# Altium schematic sheet Power.schdoc
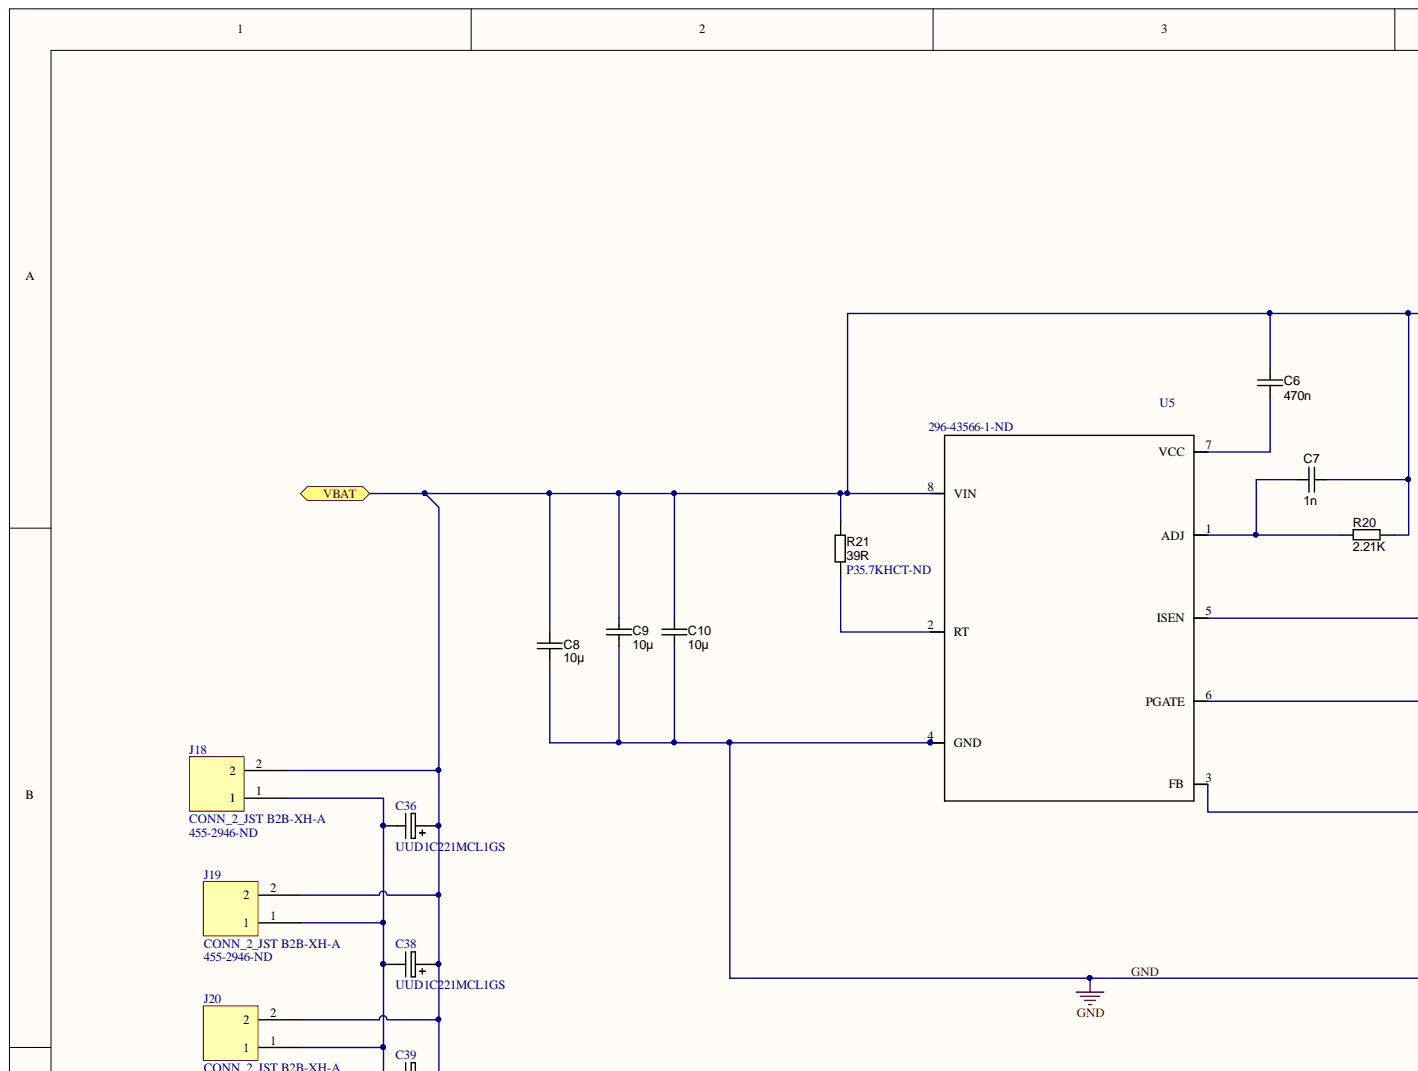
[diagram p0: Power.schdoc, top left]
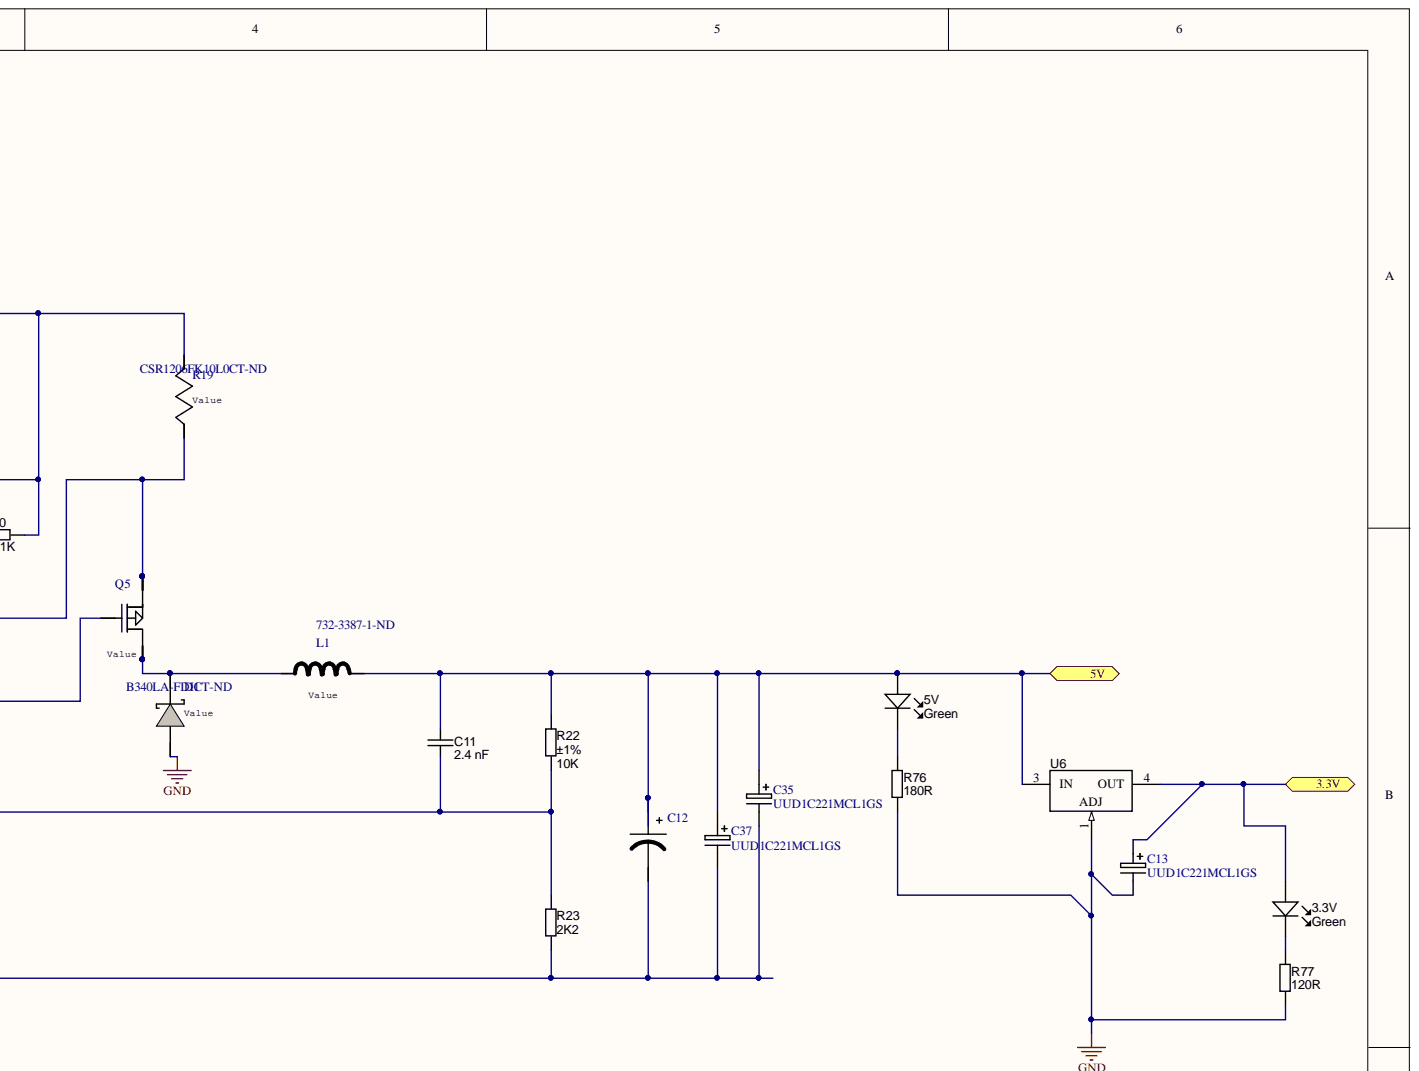
[diagram p1: Power.schdoc, top right]
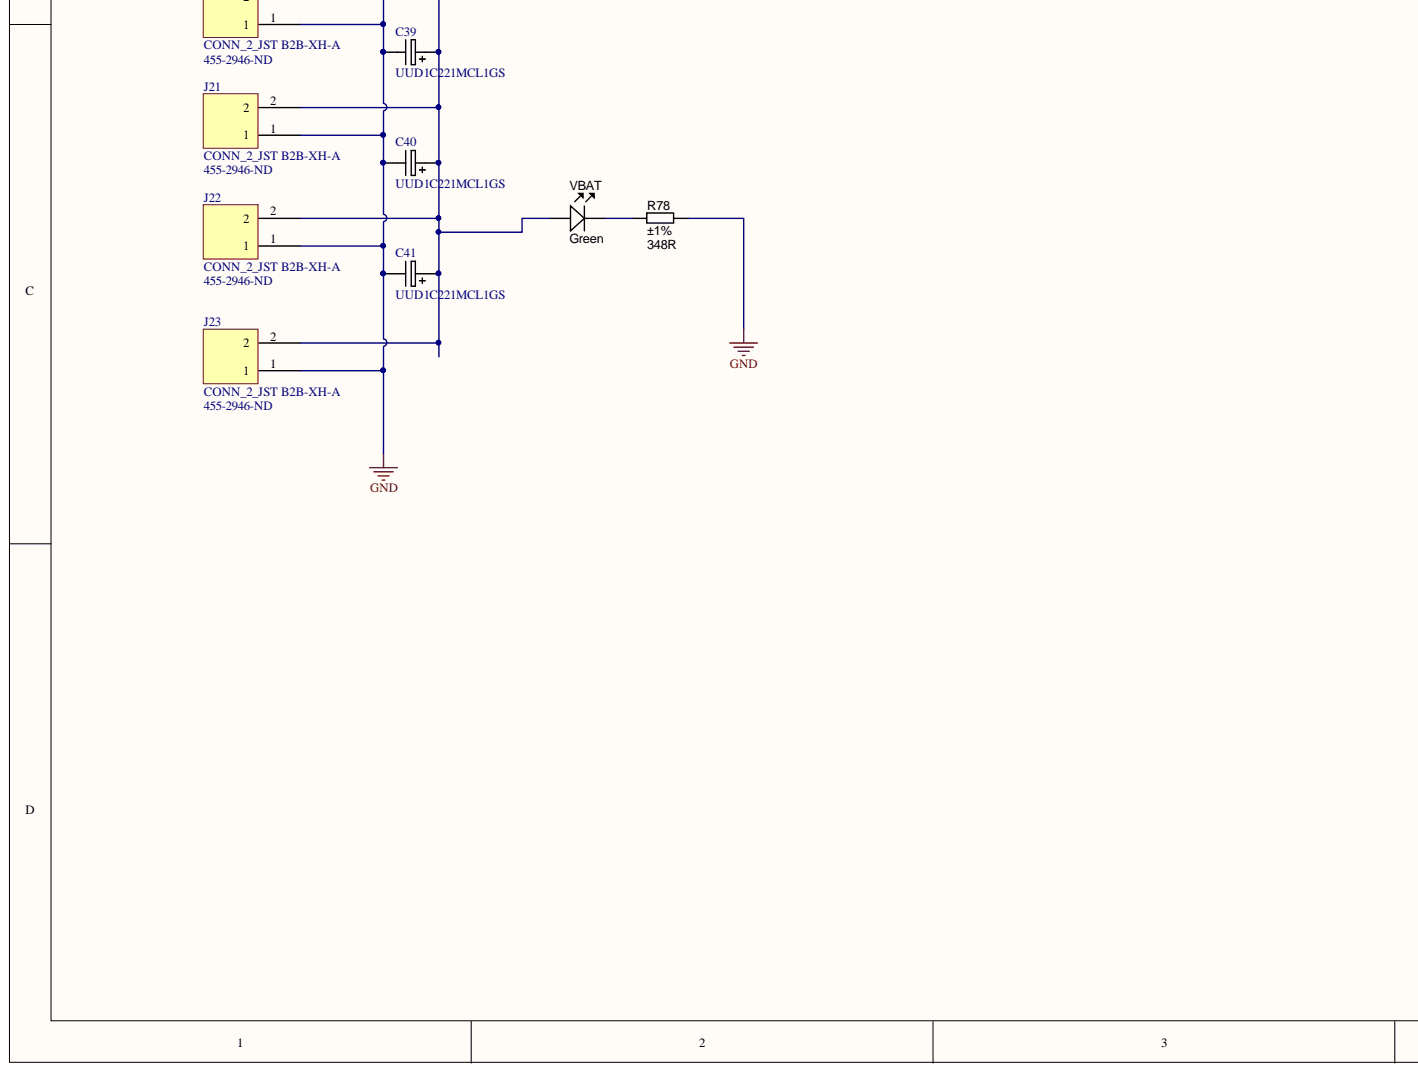
[diagram p2: Power.schdoc, bottom left]
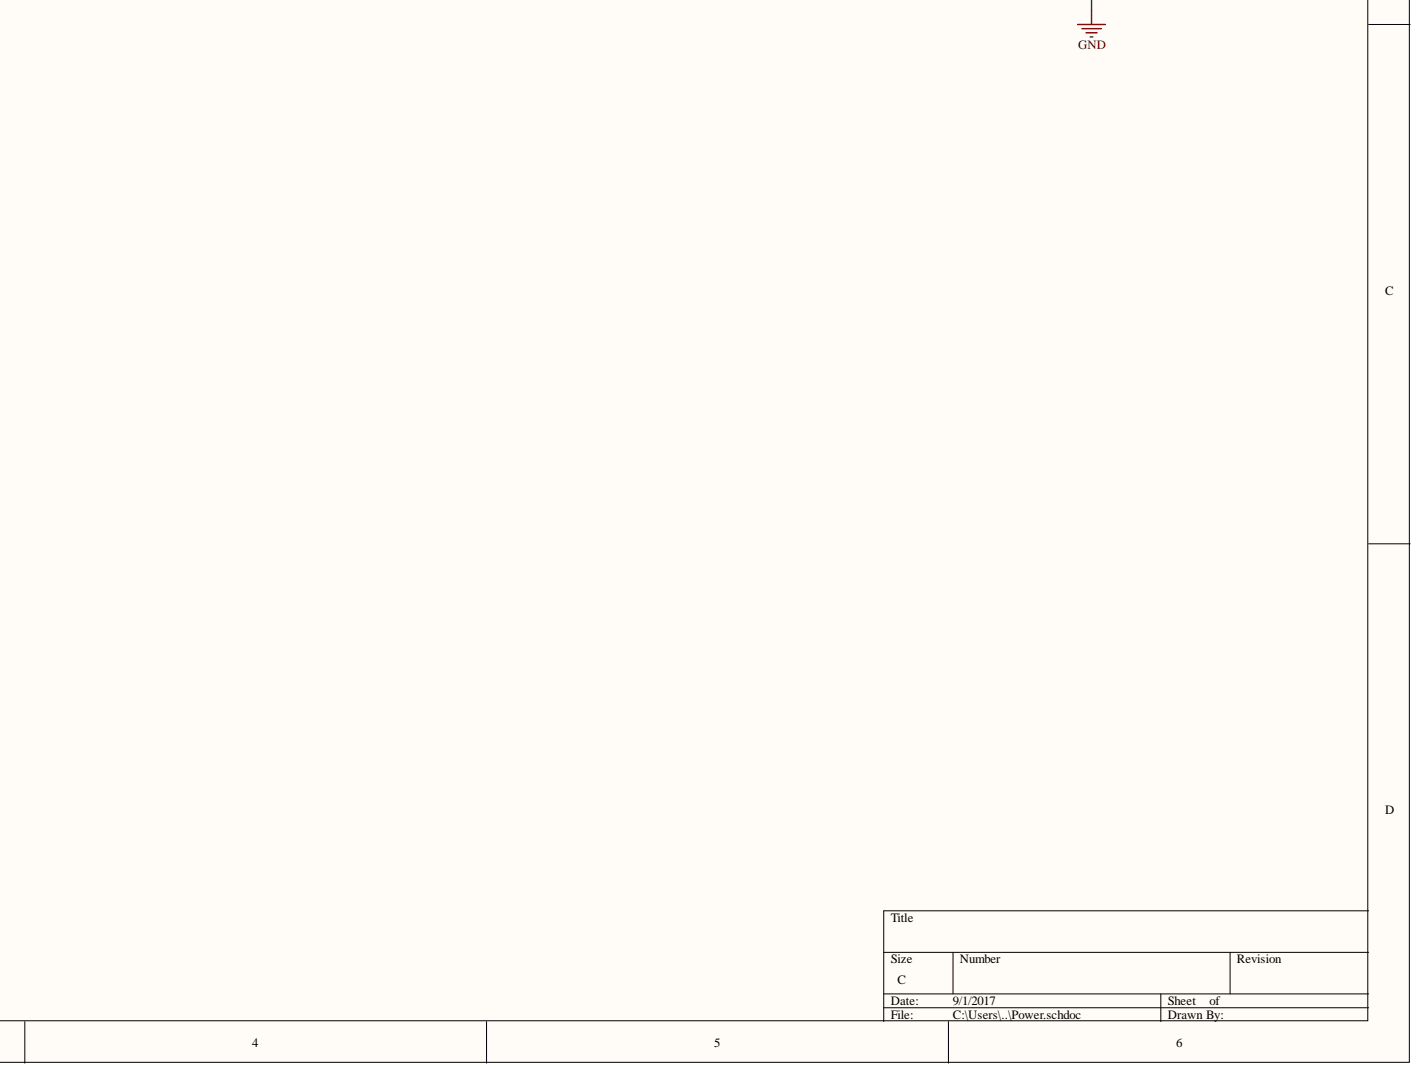
[diagram p3: Power.schdoc, bottom right]

## Parts
3.3V  Green  Voltage - Forward (Vf) @ 20mA: 3.3V  [LED-0603-GREEN]
5V  Green  [LED-0603-GREEN]
C6  value 470n  [CAPC2012X135N]  (hidden comment: approved sub OK)
C7  value 1n  [CAPC1608X90N]  (hidden comment: approved sub OK)
C8  value 10µ  [CAPC3216X180N]  (hidden comment: approved sub OK)
C9  value 10µ  [CAPC3216X180N]  (hidden comment: approved sub OK)
C10  value 10µ  [CAPC3216X180N]  (hidden comment: approved sub OK)
C11  value 2.4 nF  [CAPC1608X90N]  (hidden comment: approved sub OK)
C12  6TPU47MSI  [CAPC2012X135N]  (value not drawn)
C13  UUD1C221MCL1GS  [NICH 6.3mm 7.7mm]
C35  UUD1C221MCL1GS  [NICH 6.3mm 7.7mm]
C36  UUD1C221MCL1GS  [NICH 6.3mm 7.7mm]
C37  UUD1C221MCL1GS  [NICH 6.3mm 7.7mm]
C38  UUD1C221MCL1GS  [NICH 6.3mm 7.7mm]
C39  UUD1C221MCL1GS  [NICH 6.3mm 7.7mm]
C40  UUD1C221MCL1GS  [NICH 6.3mm 7.7mm]
C41  UUD1C221MCL1GS  [NICH 6.3mm 7.7mm]
D1  Supplier Part Number 1: B340LA-FDICT-ND  [SMA]  (hidden comment: B340LA-13-F)
J18  CONN_2_JST B2B-XH-A  Supplier Part Number 1: 455-2946-ND  [CONN_JST_XT_TH_2]
J19  CONN_2_JST B2B-XH-A  Supplier Part Number 1: 455-2946-ND  [CONN_JST_XT_TH_2]
J20  CONN_2_JST B2B-XH-A  Supplier Part Number 1: 455-2946-ND  [CONN_JST_XT_TH_2]
J21  CONN_2_JST B2B-XH-A  Supplier Part Number 1: 455-2946-ND  [CONN_JST_XT_TH_2]
J22  CONN_2_JST B2B-XH-A  Supplier Part Number 1: 455-2946-ND  [CONN_JST_XT_TH_2]
J23  CONN_2_JST B2B-XH-A  Supplier Part Number 1: 455-2946-ND  [CONN_JST_XT_TH_2]
L1  Supplier Part Number 1: 732-3387-1-ND  [IND_WE-LHMI-SM]  (hidden comment: 74437368022)
Q5  CSD25402Q3A  [TRANS_NEXFET_Q3A]  (value not drawn)
R19  CSR1206FK10L0  Supplier Part Number 1: CSR1206FK10L0CT-ND  [1206]
R20  value 2.21K  [RESC1608X55N]  (hidden comment: Thick Film)
R21  value 39R  Supplier Part Number 1: P35.7KHCT-ND  [RESC1608X55N]  (hidden comment: Thick Film)
R22  value 10K  Tolerance: ±1%  [RESC1608X55N]  (hidden comment: Thick Film)
R23  value 2K2  [RESC1608X55N]  (hidden comment: Thick Film)
R76  value 180R  [RESC1608X55N]  (hidden comment: Thick Film)
R77  value 120R  [RESC1608X55N]  (hidden comment: Thick Film)
R78  value 348R  Tolerance: ±1%  [RESC1608X55N]  (hidden comment: Thin Film)
U5  Supplier Part Number 1: 296-43566-1-ND  [MUY08A]  (hidden comment: LM25085AMY/NOPB)
U6  LD1085D2T33R  [D2PAK-3]  (value not drawn)
VBAT  Green  [LED-0603-GREEN]

## Ports
3.3V, 5V, VBAT

## Nets
3.3V: 3.3V.A C13.+ U6.4 ; port 3.3V
5V: 5V.A C11.1 C12.1 C35.+ C37.+ L1.2 R22.1 U6.3 ; port 5V
GND: C8.2 C9.2 C10.2 C12.2 C13.- C35.- C36.- C37.- C38.- C39.- C40.- C41.- D1.2 J18.1 J19.1 J20.1 J21.1 J22.1 J23.1 R23.2 R76.2 R77.2 R78.2 U5.4(GND) U5.9 U6.1
VBAT: C6.1 C7.2 C8.1 C9.1 C10.1 C36.+ C38.+ C39.+ C40.+ C41.+ J18.2 J19.2 J20.2 J21.2 J22.2 J23.2 R19.1 R20.2 R21.1 U5.8(VIN) VBAT.A ; port VBAT
Net3.3V_K: 3.3V.K R77.1
Net5V_K: 5V.K R76.1
NetC6_2: C6.2 U5.7(VCC)
NetC7_1: C7.1 R20.1 U5.1(ADJ)
NetC11_2: C11.2 R22.2 R23.1 U5.3(FB)
NetD1_1: D1.1 L1.1 Q5.1 Q5.2 Q5.3
NetQ5_4: Q5.4 U5.6(PGATE)
NetQ5_5: Q5.5 Q5.6 Q5.7 Q5.8 Q5.9 R19.2 U5.5(ISEN)
NetR21_2: R21.2 U5.2(RT)
NetR78_1: R78.1 VBAT.K

## Library data of the parts
3.3V = LED_SMD_Green_0603_525nm_350mcd: LED 0603 SMD Green 525nm 350mcd 20mA; Lens Style/Size: Rectangle with Flat Top, 1.2mm x 0.8mm; Ratings: 200mcd min; 350 mcd typ @ 20mA; SCDType: source; Viewing Angle: 120°; Voltage - Forward (Vf) @ 10mA: 3.1V; Color: Green; Component Kind: Standard; ComponentLink1Description: http://www.mouser.com/ds/2/216/APT1608ZGCK-262051.pdf; ComponentLink1URL: http://www.mouser.com/ds/2/216/APT1608ZGCK-262051.pdf; ComponentLink2Description: APT1608-WAP24 Datasheet; ComponentLink2URL: \\SVFI2\PBLShare\Eng\Electrical Engineering\Hardware\Altium\Libraries and Templates\Unreleased Components\Part Number Request 2013-10-02\APT1608-WAP24(Ver.1B).pdf; Current - Test: 20mA; Library Name: PBL - Light Sources.SCHLIB; Material: InGaN; Package / Case: 0603 (1608 metric); Supplier 1: Digi-Key; Supplier Part Number 1: 160-1183-1-ND
5V VBAT = LED_SMD_Green_0603_525nm_350mcd: LED 0603 SMD Green 525nm 350mcd 20mA; Lens Style/Size: Rectangle with Flat Top, 1.2mm x 0.8mm; Ratings: 200mcd min; 350 mcd typ @ 20mA; SCDType: source; Viewing Angle: 120°; Voltage - Forward (Vf) @ 10mA: 3.1V; Voltage - Forward (Vf) @ 20mA: 3.3V; Color: Green; Component Kind: Standard; ComponentLink1Description: http://www.mouser.com/ds/2/216/APT1608ZGCK-262051.pdf; ComponentLink1URL: http://www.mouser.com/ds/2/216/APT1608ZGCK-262051.pdf; ComponentLink2Description: APT1608-WAP24 Datasheet; ComponentLink2URL: \\SVFI2\PBLShare\Eng\Electrical Engineering\Hardware\Altium\Libraries and Templates\Unreleased Components\Part Number Request 2013-10-02\APT1608-WAP24(Ver.1B).pdf; Current - Test: 20mA; Library Name: PBL - Light Sources.SCHLIB; Material: InGaN; Package / Case: 0603 (1608 metric); Supplier 1: Digi-Key; Supplier Part Number 1: 160-1183-1-ND
C6 = CAPC_470n_0805_X7R_16V_10%: Capacitor SMD Cer 470nF 10% 16V X7R 0805; Manufacturer 1: AVX Corporation; Manufacturer Part Number 1: 0805YC474KAT2A; Ratings: 16V; X7R; SCDType: undef; Component Kind: Standard; Library Name: PBL - Capacitors.SCHLIB; Operating Temperature: -55°C ~ 125°C; Package / Case: 0805 (2012 metric); Supplier 1: Digi-Key; Supplier Part Number 1: 478-1403-1-ND; Tolerance: ±10%
C7 = CAPC_1n_0603_X7R_100V_10%: Capacitor SMD Cer 1nF 10% 100V X7R 0603; Manufacturer 1: Murata Electronics North America; Manufacturer Part Number 1: GCM188R72A102KA37D; Material: ceramic; Ratings: 100V; X7R; SCDType: spec; Component Kind: Standard; ComponentLink1Description: http://search.murata.co.jp/Ceramy/image/img/PDF/ENG/GCM188R72A102KA37.pdf; ComponentLink1URL: http://search.murata.co.jp/Ceramy/image/img/PDF/ENG/GCM188R72A102KA37.pdf; Library Name: PBL - Capacitors.SCHLIB; Operating Temperature: -55°C ~ 125°C; Package / Case: 0603 (1608 metric); Size / Dimension: 0.063" L x 0.031" W (1.60mm x 0.80mm); Supplier 1: Digi-Key; Supplier Part Number 1: 490-4780-1-ND; Thickness (Max): 0.035" (0.90mm); Tolerance: ±10%
C8-C10 = CAPC_10u_1206_16V: Capacitor SMD Cer 10uF 10% 16V X7R 1206; Manufacturer 1: Kemet; Manufacturer Part Number 1: C1206C106K4RACTU; Material: ceramic; Ratings: 16V; X7R; SCDType: spec; Component Kind: Standard; Library Name: PBL - Capacitors.SCHLIB; Package / Case: 1206 (3216 metric); Supplier 1: Digi-Key; Supplier Part Number 1: 399-3525-1-ND; Tolerance: ±10%
C11 = CAPC_2n4_50V_0603: Capacitor SMD Cer 2400pF  50V NP0 0603; Ratings: 100V; NP0; SCDType: source*; Tolerance: ±0.25pF; Component Kind: Standard; Library Name: PBL - Capacitors.SCHLIB; Operating Temperature: -55°C ~ 125°C; Package / Case: 0603 (1608 metric); Supplier 1: Digi-Key; Supplier Part Number 1: 490-3282-1-ND
C12 = CAPT_47u_6.3V_0805_6TPU47MSI: CAP TANT POLY 47UF 6.3V 0805; Manufacturer_Name: Panasonic; Component Kind: Standard; Library Name: PBL - Capacitors.SCHLIB; Manufacturer_Part_Number: 6TPU47MSI; RefDes: RefDes; Supplier 1: Digi-Key; Supplier Part Number 1: P16212CT-ND; TYPE: TYPE; Value: Value; Vendor: Panasonic
C13 C35-C41 = NICHICON_220uF_16V: GENERIC CAPACITOR POLARISED; Component Kind: Standard; Library Name: SMD Capacitors Electrolytic.SchLib; Supplier 1: Digi-Key; Supplier Part Number 1: 493-2264-1-ND
D1 = B340LA-13-F: Imported; Manufacturer_Name: Diodes Inc.; Component Kind: Standard; Library Name: 2016-02-21_13-02-55.SchLib; Manufacturer_Part_Number: B340LA-13-F; RefDes: RefDes; Supplier 1: Digi-Key; Type: Type; Value: Value; Vendor: Diodes Inc.
J18-J23 = CONN_2_JST B2B-XH-A: CONN HEADER XH SIDE 2POS 2.5MM; Component Kind: Standard; Supplier 1: Digi-Key; Library Name: RyanMasterLib.SchLib
L1 = 74437368022: Imported; Manufacturer: Wurth Elektronik; Vendor: Wurth Elektronik eiSos; Component Kind: Standard; Library Name: 2016-02-21_13-02-55.SchLib; Manufacturer_Name: Wurth Elektronik; Manufacturer_Part_Number: 74437368022; PACKAGE_DESIGNATOR: IND_WE-LHMI-SM; RefDes: RefDes; Supplier 1: Digi-Key; TYPE: TYPE; Value: Value
Q5 = CSD25402Q3A: Imported; Manufacturer: Texas Instruments; ChType: P; CompType: MOSFET; IdsMax_A: -15; PIN_COUNT: 9; QgTyp_nC: 7.5; Rdson45_ohm: 0.0077; Value1: 0.0077 ohm; Value2: 7.5 nC; VdsMax_V: -20; Vgs_V: 12; Component Kind: Standard; LatestRevisionNote: Added parts.; Library Name: 2016-02-21_13-02-55.SchLib; Manufacturer_Name: Texas Instruments; Manufacturer_Part_Number: CSD25402Q3A; MountType: SMT; PackageReference: SON 3.3x3.3mm; PartNumber: CSD25402Q3A; Published: 2008-06-19; RefDes: RefDes; RoHS: Y; Supplier 1: Digi-Key; Supplier Part Number 1: 296-38916-1-ND; TYPE: TYPE; Value: Value
R19 = CSR1206FK10L0: Imported; Manufacturer_Name: Stackpole Electronics Inc; Component Kind: Standard; Library Name: 2016-02-21_13-02-55.SchLib; Manufacturer_Part_Number: CSR1206FK10L0; RefDes: RefDes; Supplier 1: Digi-Key; TYPE: TYPE; Value: Value; Vendor: Stackpole Electronics Inc
R20 = RES_2.21K_0603_1%: Resistor SMD chip 2.21k Ohm 0.1W 1% 0603; Ratings: 1/10 W; SCDType: spec; Temperature Coefficient: ±100ppm/°C; Tolerance: ±5%; Component Kind: Standard; ComponentLink1Description: http://www.yageo.com/documents/recent/PYu-RC0603_51_RoHS_L_4.pdf; ComponentLink1URL: http://www.yageo.com/documents/recent/PYu-RC0603_51_RoHS_L_4.pdf; ComponentLink2Description: http://www.samsungsem.com/servlet/FileDownload?filename=productcatalog/pdf/Chip_Resistor.pdf; ComponentLink2URL: http://www.samsungsem.com/servlet/FileDownload?filename=productcatalog/pdf/Chip_Resistor.pdf; ComponentLink3Description: http://www.seielect.com/Catalog/SEI-RMCF_RMCP.pdf; ComponentLink3URL: http://www.seielect.com/Catalog/SEI-RMCF_RMCP.pdf; ComponentLink4Description: http://rohmfs.rohm.com/en/products/databook/datasheet/passive/resistor/chip_resistor/mcr_g.pdf; ComponentLink4URL: http://rohmfs.rohm.com/en/products/databook/datasheet/passive/resistor/chip_resistor/mcr_g.pdf; ComponentLink5Description: http://industrial.panasonic.com/www-cgi/jvcr13pz.cgi?E+PZ+3+AOA0001+ERJ3GEYJ222V+7+WW; ComponentLink5URL: http://industrial.panasonic.com/www-cgi/jvcr13pz.cgi?E+PZ+3+AOA0001+ERJ3GEYJ222V+7+WW; Library Name: PBL - Resistors.SCHLIB; Material: Thick Film; Package / Case: 0603 (1608 metric); Supplier 1: Digi-Key; Supplier Part Number 1: P2.21KHCT-ND
R21 = RES_35.7K_0603_1%: Resistor SMD chip 35.7K Ohm 0.1W 1% 0603; Ratings: 1/10 W; SCDType: spec; Tolerance: ±5%; Component Kind: Standard; ComponentLink1Description: http://www.vishay.com/doc?20035; ComponentLink1URL: http://www.vishay.com/doc?20035; ComponentLink2Description: http://www.yageo.com/documents/recent/PYu-RC0603_51_RoHS_L_4.pdf; ComponentLink2URL: http://www.yageo.com/documents/recent/PYu-RC0603_51_RoHS_L_4.pdf; Library Name: PBL - Resistors.SCHLIB; Package / Case: 0603 (1608 metric); Supplier 1: Digi-Key
R22 = RES_10K_0603_1%: Resistor SMD chip 10k Ohm 0.1W 1% 0603; Manufacturer 1: Yageo; Manufacturer Part Number 1: RC0603FR-0710KL; Ratings: 1/10W; SCDType: spec; Component Kind: Standard; Library Name: PBL - Resistors.SCHLIB; Package / Case: 0603 (1608 Metric); Supplier 1: Digi-Key; Supplier Part Number 1: 311-10.0KHRCT-ND
R23 = RES_2K2_0603_1%: Resistor SMD chip 2.2k Ohm 0.1W 1% 0603; Ratings: 1/10 W; SCDType: spec; Temperature Coefficient: ±100ppm/°C; Tolerance: ±5%; Component Kind: Standard; ComponentLink1Description: http://www.yageo.com/documents/recent/PYu-RC0603_51_RoHS_L_4.pdf; ComponentLink1URL: http://www.yageo.com/documents/recent/PYu-RC0603_51_RoHS_L_4.pdf; ComponentLink2Description: http://www.samsungsem.com/servlet/FileDownload?filename=productcatalog/pdf/Chip_Resistor.pdf; ComponentLink2URL: http://www.samsungsem.com/servlet/FileDownload?filename=productcatalog/pdf/Chip_Resistor.pdf; ComponentLink3Description: http://www.seielect.com/Catalog/SEI-RMCF_RMCP.pdf; ComponentLink3URL: http://www.seielect.com/Catalog/SEI-RMCF_RMCP.pdf; ComponentLink4Description: http://rohmfs.rohm.com/en/products/databook/datasheet/passive/resistor/chip_resistor/mcr_g.pdf; ComponentLink4URL: http://rohmfs.rohm.com/en/products/databook/datasheet/passive/resistor/chip_resistor/mcr_g.pdf; ComponentLink5Description: http://industrial.panasonic.com/www-cgi/jvcr13pz.cgi?E+PZ+3+AOA0001+ERJ3GEYJ222V+7+WW; ComponentLink5URL: http://industrial.panasonic.com/www-cgi/jvcr13pz.cgi?E+PZ+3+AOA0001+ERJ3GEYJ222V+7+WW; Library Name: PBL - Resistors.SCHLIB; Material: Thick Film; Package / Case: 0603 (1608 metric); Supplier 1: Digi-Key; Supplier Part Number 1: 311-2.20KHRCT-ND
R76 = RES_180R_0603_5%: Resistor SMD chip 180 Ohm 0.1W 5% 0603; Manufacturer 1: Vishay Dale; Manufacturer Part Number 1: CRCW0603180RJNEA; Ratings: 1/10 W; SCDType: spec; Component Kind: Standard; ComponentLink1Description: http://www.vishay.com/doc?20035; ComponentLink1URL: http://www.vishay.com/doc?20035; ComponentLink2Description: http://www.yageo.com/documents/recent/PYu-RC0603_51_RoHS_L_4.pdf; ComponentLink2URL: http://www.yageo.com/documents/recent/PYu-RC0603_51_RoHS_L_4.pdf; Library Name: PBL - Resistors.SCHLIB; Manufacturer 2: Yageo; Manufacturer Part Number 2: RC0603JR-07180RL; Material: Thick Film; Package / Case: 0603 (1608 metric); Supplier 1: Digi-Key; Supplier 2: Digi-Key; Supplier Part Number 1: 541-180GCT-ND; Supplier Part Number 2: 311-180GRCT-ND; Tolerance: ±5%
R77 = RES_120R_0603_5%: Resistor SMD chip 120 Ohm 0.1W 5% 0603; Manufacturer 1: Vishay Dale; Manufacturer Part Number 1: CRCW0603120RJNEA; Ratings: 1/10 W; SCDType: spec; Component Kind: Standard; ComponentLink1Description: http://www.vishay.com/doc?20035; ComponentLink1URL: http://www.vishay.com/doc?20035; ComponentLink2Description: http://www.yageo.com/documents/recent/PYu-RC0603_51_RoHS_L_4.pdf; ComponentLink2URL: http://www.yageo.com/documents/recent/PYu-RC0603_51_RoHS_L_4.pdf; Library Name: PBL - Resistors.SCHLIB; Manufacturer 2: Yageo; Manufacturer Part Number 2: RC0603JR-07120RL; Material: Thick Film; Package / Case: 0603 (1608 metric); Supplier 1: Digi-Key; Supplier 2: Digi-Key; Supplier Part Number 1: 541-120GCT-ND; Supplier Part Number 2: 311-120GRCT-ND; Tolerance: ±5%
R78 = RES_348R_0603_1%: Resistor SMD chip 348 Ohm 0.1W 1% 0603; Manufacturer 1: Yageo; Manufacturer Part Number 1: RC0603FR-07348RL; Ratings: 1/10 W; SCDType: spec; Component Kind: Standard; Library Name: PBL - Resistors.SCHLIB; Material: Thin Film; Package / Case: 0603 (1608 metric); Supplier 1: Digi-Key; Supplier Part Number 1: 311-348HRCT-ND
U5 = LM25085AMY/NOPB: Imported; Manufacturer_Name: Texas Instruments; Manufacturer_Part_Number: LM5085MY/NOPB; Component Kind: Standard; Library Name: 2016-02-21_13-02-55.SchLib; RefDes: RefDes; Supplier 1: Digi-Key; TYPE: TYPE; Value: Value; Vendor: Texas Instruments
U6 = LD1085D2T33R: Voltage Regulator IC, IC REG LDO 3.3V 3A D2PAK; Ratings: 40V; 1.5A; SCDType: spec*; Tolerance: 0.04%; Value: variable; Voltage Regulator Type: Adjustable Positive Linear; Component Kind: Standard; Library Name: PBL - Regulators.SCHLIB; Package / Case: D2PAK; Supplier 1: Digi-Key; Supplier Part Number 1: 497-1222-1-ND
